# Altium schematic sheet 01_OLEDMCU_OLED.SchDoc(01_OLEDMCU_OLED)
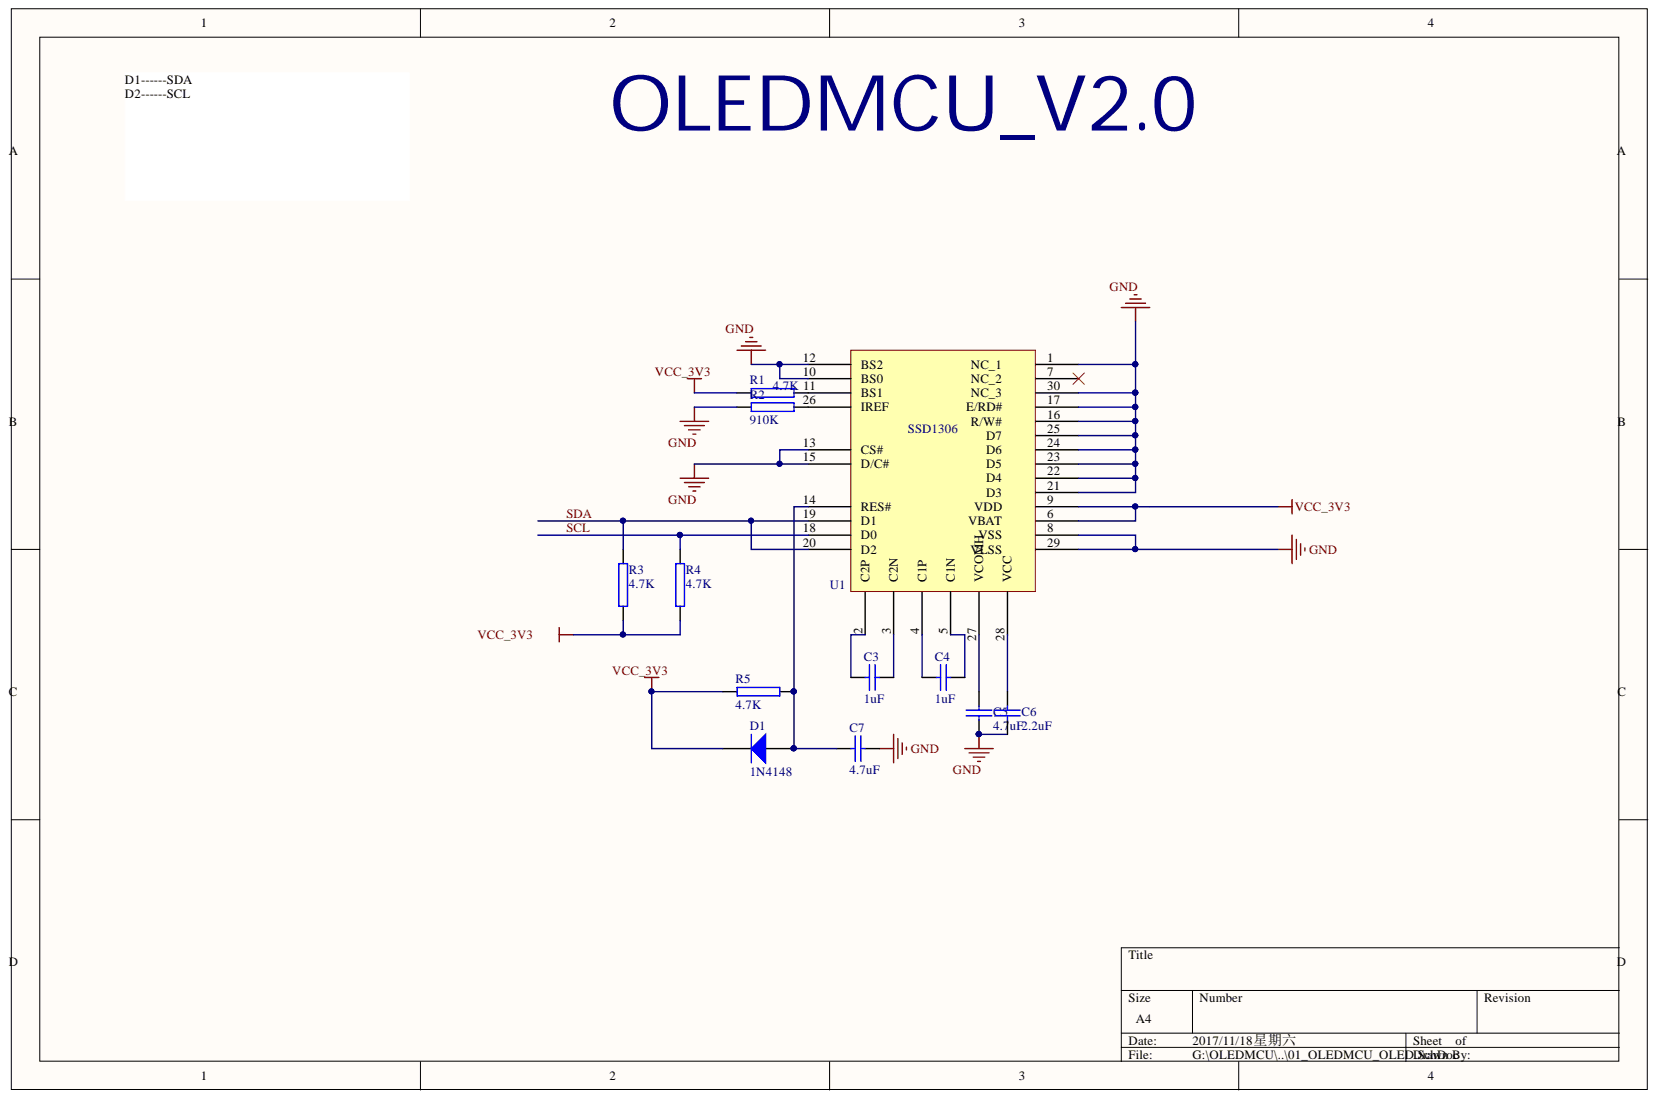
[diagram p0: 01_OLEDMCU_OLED.SchDoc]

## Parts
C3  1uF  [C0603]
C4  1uF  [C0603]
C5  4.7uF  [C0603]
C6  2.2uF  [C0603]
C7  4.7uF  [C0603]
D1  1N4148  [1n4148]
R1  4.7K  [R0603]
R2  910K  [R0603]
R3  4.7K  [R0603]
R4  4.7K  [R0603]
R5  4.7K  [R0603]
U1  SSD1306  [SSD1306]

## Nets
GND: C5.2 C6.2 C7.2 R2.1 U1.1 U1.8 U1.10(BS0) U1.12(BS2) U1.13(CS#) U1.15(D/C#) U1.16 U1.17 U1.21 U1.22 U1.23 U1.24 U1.25 U1.29 U1.30
VCC_3V3: D1.2 R1.1 R3.1 R4.1 R5.1 U1.6 U1.9
SCL: R4.2 U1.18(D0)
SDA: R3.2 U1.19(D1) U1.20(D2)
NetC3_1: C3.1 U1.2(C2P)
NetC3_2: C3.2 U1.3(C2N)
NetC4_1: C4.1 U1.5(C1N)
NetC4_2: C4.2 U1.4(C1P)
NetC5_1: C5.1 U1.27(VCOMH)
NetC6_1: C6.1 U1.28(VCC)
NetC7_1: C7.1 D1.1 R5.2 U1.14(RES#)
NetR1_2: R1.2 U1.11(BS1)
NetR2_2: R2.2 U1.26(IREF)

Not connected: U1 7

## Library data of the parts
C3-C7 = C0603: 贴片电容; Component Kind: Standard; Library Name: Schlib_OLEDMCU.SchLib
D1 = 1N4148: 二极管; Component Kind: Standard; Library Name: Schlib_OLEDMCU.SchLib
R1-R5 = R0603: 贴片电阻; Component Kind: Standard; Library Name: Schlib_OLEDMCU.SchLib
U1 = SSD1306: 0.96寸OLED; Component Kind: Standard; Library Name: Schlib_OLEDMCU.SchLib
